# Altium schematic sheet Power_block.SchDoc
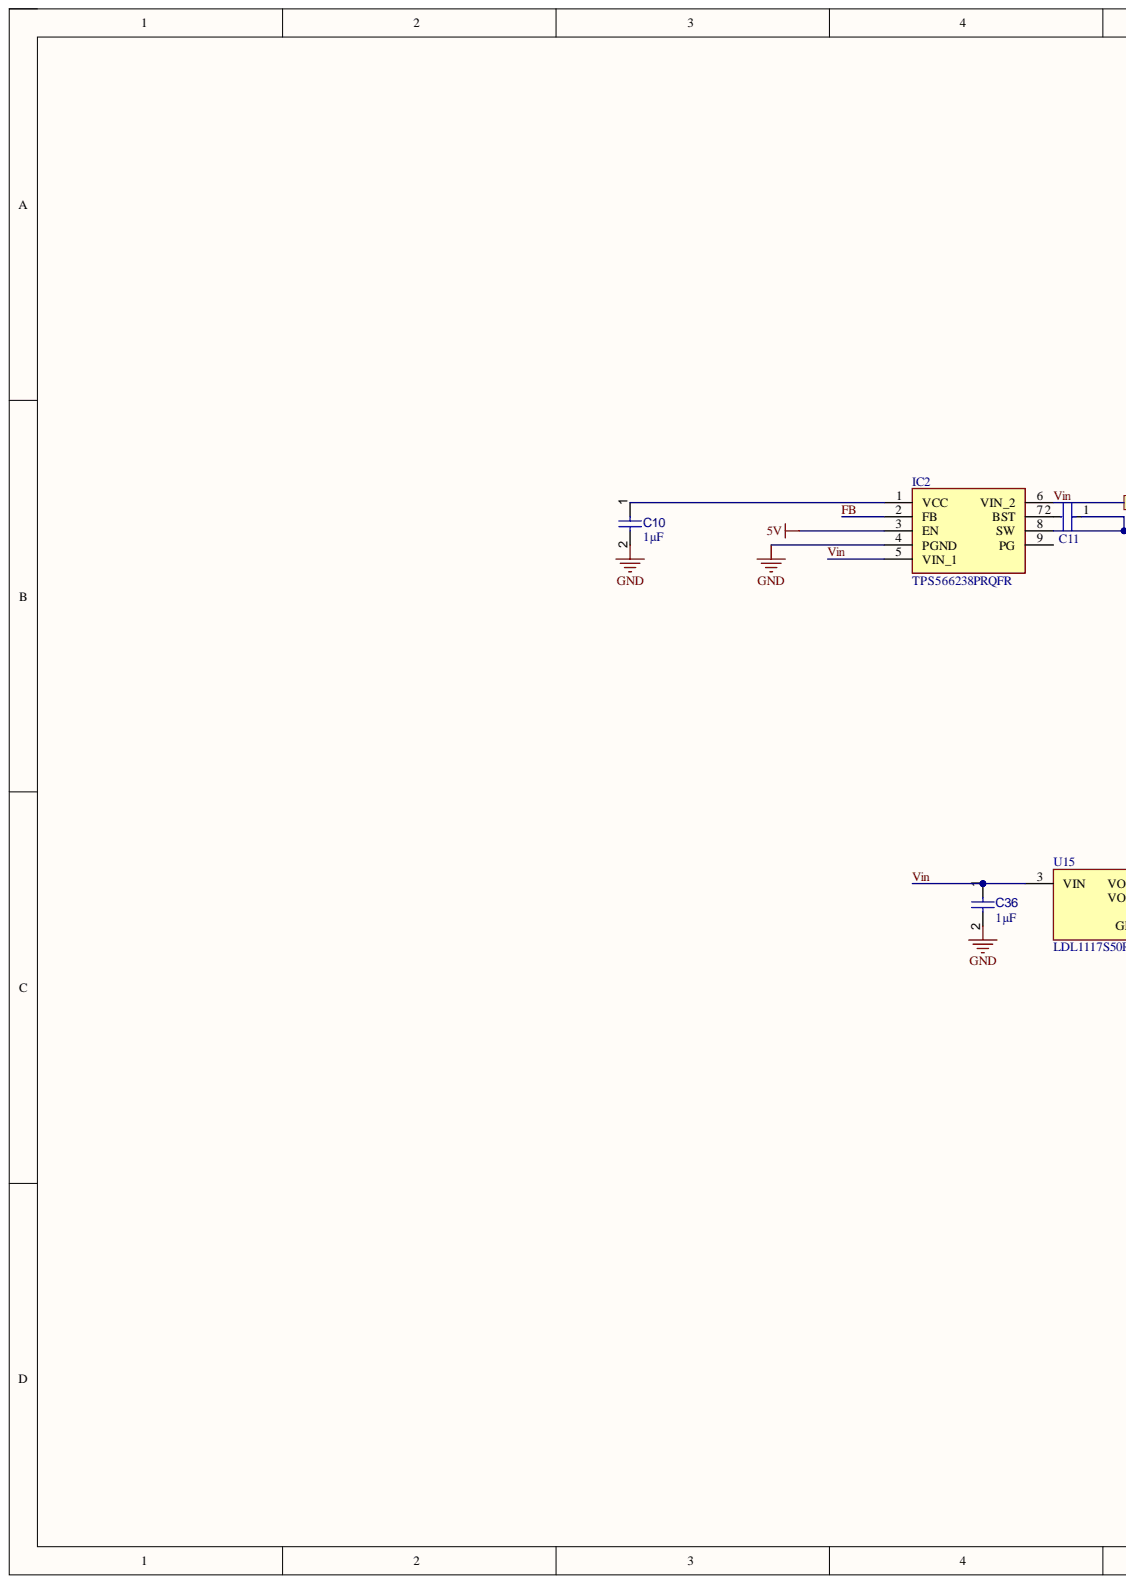
[diagram p0: Power_block.SchDoc, left]
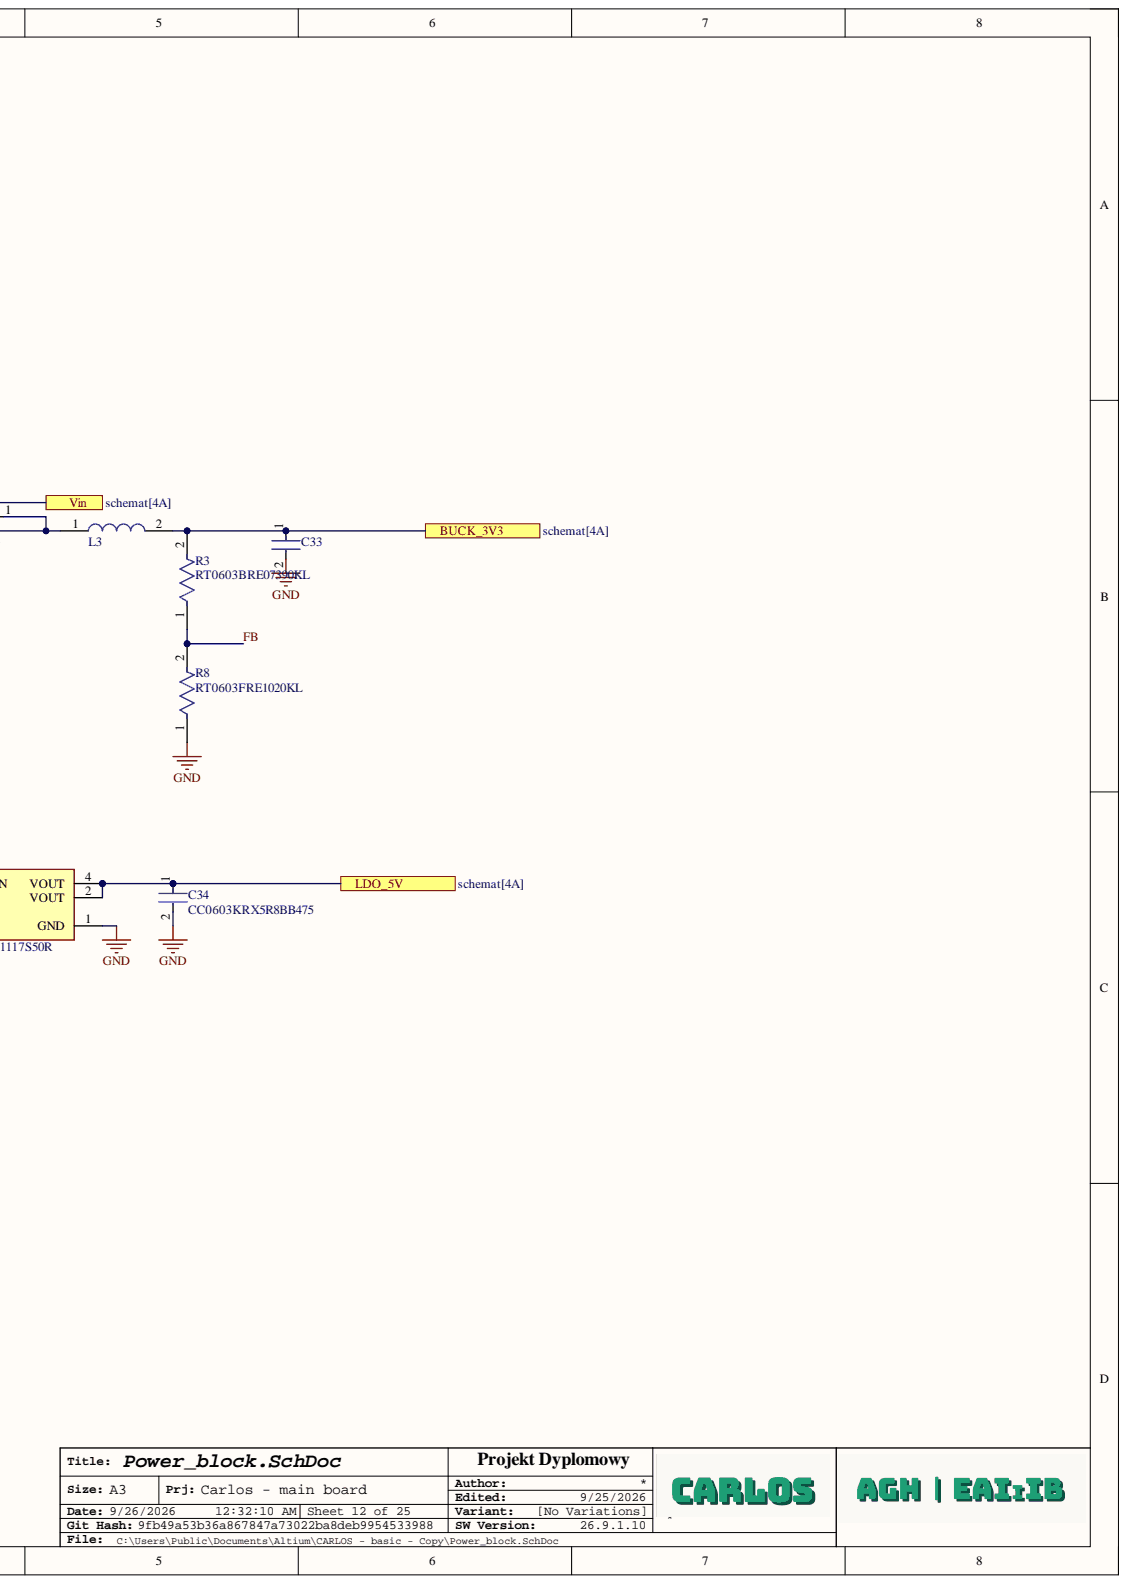
[diagram p1: Power_block.SchDoc, right]

## Parts
C10  value 1µF  [CAPC0603(1608)100_L]  (hidden comment: Capacitor 1µF +/-40% 50V 0603)
C11  CL10B104KC8NNNC  [FP-CL10-IPC_A]  (value not drawn)
C33  CL10A226MO7JZNC  [FP-CL10-IPC_B]  (value not drawn)
C34  CC0603KRX5R8BB475  [FP-CC0603-DA-MFG]
C36  value 1µF  [CAPC1608X90X40LL10T20]  (hidden comment: Capacitor 1 uF +/- 10% 25 V 0603)
IC2  TPS566238PRQFR  [TPS566238PRQFR]
L3  DFE252012P-2R2M=P2  [DFE2HCAH1R0MJ0L]  (value not drawn)
R3  RT0603BRE07390KL  [FP-RT0603-MFG]
R8  RT0603FRE1020KL  [FP-RT0603-MFG]
U15  LDL1117S50R  [FP-SOT-223-0046067_14-MFG]

## Ports
BUCK_3V3, LDO_5V, Vin

## Nets
5V: IC2.3(EN)
GND: C10.2 C33.2 C34.2 C36.2 IC2.4(PGND) R8.1 U15.1(GND)
BUCK_3V3: C33.1 L3.2 R3.2 ; port BUCK_3V3
FB: IC2.2(FB) R3.1 R8.2
LDO_5V: C34.1 U15.2(VOUT) U15.4(VOUT) ; port LDO_5V
Vin: C36.1 IC2.5(VIN_1) IC2.6(VIN_2) U15.3(VIN) ; port Vin
NetC10_1: C10.1 IC2.1(VCC)
NetC11_1: C11.1 IC2.8(SW) L3.1
NetC11_2: C11.2 IC2.7(BST)

Not connected: IC2 9(PG)

## Library data of the parts
C10 = CMP-001-00078-4: Chip Capacitor, 1µF +/-40%, 50V, 0603, Thickness 1 mm; Tolerance: 10%; Case/Package: 0603; Component Kind: Standard; Max Operating Temperature: 85°C; Min Operating Temperature: -55°C; Mounting Technology: SMT; Pins: 2; Voltage Rating: 50V; Library Name: Jakub Sikora
C11 = CMP-13271-004036-1: Cap Ceramic 100nF 100V X7R ±10% Pad SMD 0603 +125°C T/R; Capacitance: 100nF; Case Code (Imperial): 0603; Case Code (Metric): 1608; Case/Package: 0603; Component Kind: Standard; Length: 0.063inch; Library Name: Altium Content Vault; Max Operating Temperature: 125°C; Min Operating Temperature: -55°C; Mount: Surface Mount; Packaging: Cut Tape; Thickness: 0.035inch; Tolerance: 10%; Voltage Rating: 100V; Width: 0.031inch
C33 = CMP-13271-002755-1: Cap Ceramic 22uF 16V X5R ±20% Pad SMD 0603 +85°C T/R; Capacitance: 22uF; Case Code (Imperial): 0603; Case Code (Metric): 1608; Case/Package: 0603; Component Kind: Standard; Library Name: Altium Content Vault; Max Operating Temperature: 85°C; Min Operating Temperature: -55°C; Mount: Surface Mount; Packaging: Tape and Reel; Tolerance: 20%; Voltage Rating: 16V
C34 = CMP-03422-001217-1: CAP CER 4.7UF 25V X5R 0603; Manufacturer: YAGEO; Material: Ceramic; Temperature Coefficient: 15%; Tolerance: 0.1; Automotive: No; Capacitance: 4.7 uF; Category: Cap; Component Kind: Standard; ComponentLink2Description: Datasheet; ComponentLink2URL: http://www.yageo.com/documents/recent/UPY-GPHC_X7R_6.3V-to-50V_18.pdf; Device Class L1: Passive Components; Device Class L2: Capacitors; Device Class L3: Ceramic Capacitors; Digikey Description: CAP CER 4.7UF 25V X5R 0603; Footprint Url: http://www.yageo.com/exep/pages/download/literatures/UPY-C_GEN_24.pdf; Height: 0.9mm; Lead Free: Yes; Library Name: Altium Content Vault; Manufacturer Part Number: CC0603KRX5R8BB475; Octopart: https://octopart.com/cc0603krx5r8bb475-yageo-77825350; Package: CC0603-DA; RoHS: TRUE; Temperature: -55°C to +125°C; Temperature Characteristic: X5R; Temperature Range High: +125°C; Temperature Range Low: -55°C; Value: 4.7 uF; Voltage: 25 V; Voltage Rating: 25 V
C36 = CMP-001-00005-6: Chip Capacitor, 1 uF, +/- 10%, 25 V, 0603 (1608 Metric); Capacitor Type: Ceramic; Voltage Rating: 25V; Case/Package: 0603; Component Kind: Standard; Max Operating Temperature: 125°C; Min Operating Temperature: -55°C; Mounting Technology: SM; Pins: 2; RoHS Compliant: True; Tolerance: 10%; Library Name: Jakub Sikora
IC2 = TPS566238PRQFR: Integrated Circuit; Manufacturer_Name: Texas Instruments; Arrow Part Number: TPS566238PRQFR; Arrow Price/Stock: https://www.arrow.com/en/products/tps566238prqfr/texas-instruments?utm_currency=USD&region=nac; Component Kind: Standard; Datasheet Link: https://www.ti.com/general/docs/suppproductinfo.tsp?distId=10&gotoUrl=http%3A%2F%2Fwww.ti.com%2Flit%2Fgpn%2Ftps566238; Height: 1mm; Library Name: SamacSys.SchLib; Manufacturer_Part_Number: TPS566238PRQFR; Mouser Part Number: 595-TPS566238PRQFR; Mouser Price/Stock: https://www.mouser.co.uk/ProductDetail/Texas-Instruments/TPS566238PRQFR?qs=stqOd1AaK795n4nWERKnUw%3D%3D
L3 = CMP-006-00030-1: Inductor; Manufacturer_Name: Murata Electronics; Arrow Part Number: DFE252012P-2R2M=P2; Arrow Price/Stock: https://www.arrow.com/en/products/dfe252012p-2r2mp2/murata-manufacturing?utm_currency=USD&region=nac; Component Kind: Standard; Datasheet Link: https://search.murata.co.jp/Ceramy/image/img/P02/J(E)TE243A-0024.pdf; Design Item ID: DFE252012P-2R2M=P2; Height: 1.2mm; Manufacturer_Part_Number: DFE252012P-2R2M=P2; Mouser Part Number: 81-DFE252012P-2R2MP2; Mouser Price/Stock: https://www.mouser.co.uk/ProductDetail/Murata-Electronics/DFE252012P-2R2M%3dP2?qs=KuGPmAKtFKXLyjzo39FSsQ%3D%3D; Source: SamacSys.SchLib; Library Name: Jakub Sikora
R3 = CMP-03412-014743-1: RES SMD 390K OHM 0.1% 1/10W 0603; Manufacturer: Yageo; Power Rating: 0.1W; Resistance: 390KΩ; Temperature Coefficient: 50 ppm/°C; Tolerance: 0.001; Value: 390KΩ; Voltage Rating: 75V; Automotive: No; Category: Res; Component Kind: Standard; ComponentLink2Description: Datasheet; ComponentLink2URL: http://www.yageo.com/documents/recent/PYu-RT_1-to-0.01_RoHS_L_9.pdf; Composition: Thin Film; Device Class L1: Passive Components; Device Class L2: Resistors; Device Class L3: Chip SMD Resistors; Digikey Description: RES SMD 390K OHM 0.1% 1/10W 0603; Footprint Url: http://www.yageo.com/exep/pages/download/literatures/PYu-R_Mount_10.pdf; Height: 0.55mm; Ipc Land Pattern Name: RESC16080X45; Lead Free: Yes; Library Name: Altium Content Vault; Manufacturer Part Number: RT0603BRE07390KL; Octopart: https://octopart.com/rt0603bre07390kl-yageo-51293750; Package: RT0603; RoHS: TRUE; Series: RT; Temperature: -55°C to +155°C; Temperature Range High: +155°C; Temperature Range Low: -55°C
R8 = CMP-03412-015052-1: RT0603 20KΩ 0.1W 50 ppm/°C 1.00%; Manufacturer: Yageo; Tolerance: 0.01; Voltage Rating: 75V; Automotive: No; Category: Res; Component Kind: Standard; ComponentLink2Description: Datasheet; ComponentLink2URL: http://www.yageo.com/documents/recent/PYu-RT_1-to-0.01_RoHS_L_9.pdf; Composition: Thin Film; Device Class L1: Passive Components; Device Class L2: Resistors; Device Class L3: Chip SMD Resistors; Footprint Url: http://www.yageo.com/exep/pages/download/literatures/PYu-R_Mount_10.pdf; Height: 0.55mm; Ipc Land Pattern Name: RESC16080X45; Lead Free: Yes; Library Name: Altium Content Vault; Manufacturer Part Number: RT0603FRE1020KL; Octopart: https://octopart.com/rt0603fre1020kl-yageo-16261742; Package: RT0603; Power Rating: 0.1W; Resistance: 20KΩ; RoHS: TRUE; Series: RT; Temperature: -55°C to +155°C; Temperature Coefficient: 50 ppm/°C; Temperature Range High: +155°C; Temperature Range Low: -55°C; Value: 20KΩ
U15 = CMP-12153-000005-1: IC REG LINEAR 5V 1.2A SOT223; Manufacturer: STMicroelectronics; Accuracy Percentage: 2%; Dropout Voltage: 350mV; Max Junction Temp: +150°C; Max Supply Voltage: 18V; Min Supply Voltage: 2.6V; Nominal Supply Current: 250uA; Number Of Outputs: 1; Output Current: 2A; Output Type: Fixed; Standoff Height: 0.02mm; Automotive: No; Category: IC; Component Kind: Standard; ComponentLink2Description: Datasheet; ComponentLink2URL: https://www.st.com/content/ccc/resource/technical/document/datasheet/group3/0e/5a/00/ca/10/1a/4f/a5/DM00366442/files/DM00366442.pdf/jcr:content/translations/en.DM00366442.pdf; Device Class L1: Integrated Circuits (ICs); Device Class L2: Power Management ICs; Device Class L3: Voltage Regulators - Linear; Digikey Description: IC REG LINEAR 5V 1.2A SOT223; Height: 1.9mm; Lead Free: Yes; Library Name: Altium Content Vault; Manufacturer Part Number: LDL1117S50R; Mouser Description: LDL1117 Series 1.2 A 5 V High PSRR LDO Linear Voltage Regulator - SOT-223; Octopart: https://octopart.com/ldl1117s50r-stmicroelectronics-81876164; Output Voltage: 5V; Package: SOT-223-0046067_14; RoHS: TRUE; Temperature: -40°C to +125°C; Temperature Range High: +125°C; Temperature Range Low: -40°C
